# Altium schematic sheet 04-BOUTON_LCD.SchDoc(04-BOUTON_LCD)
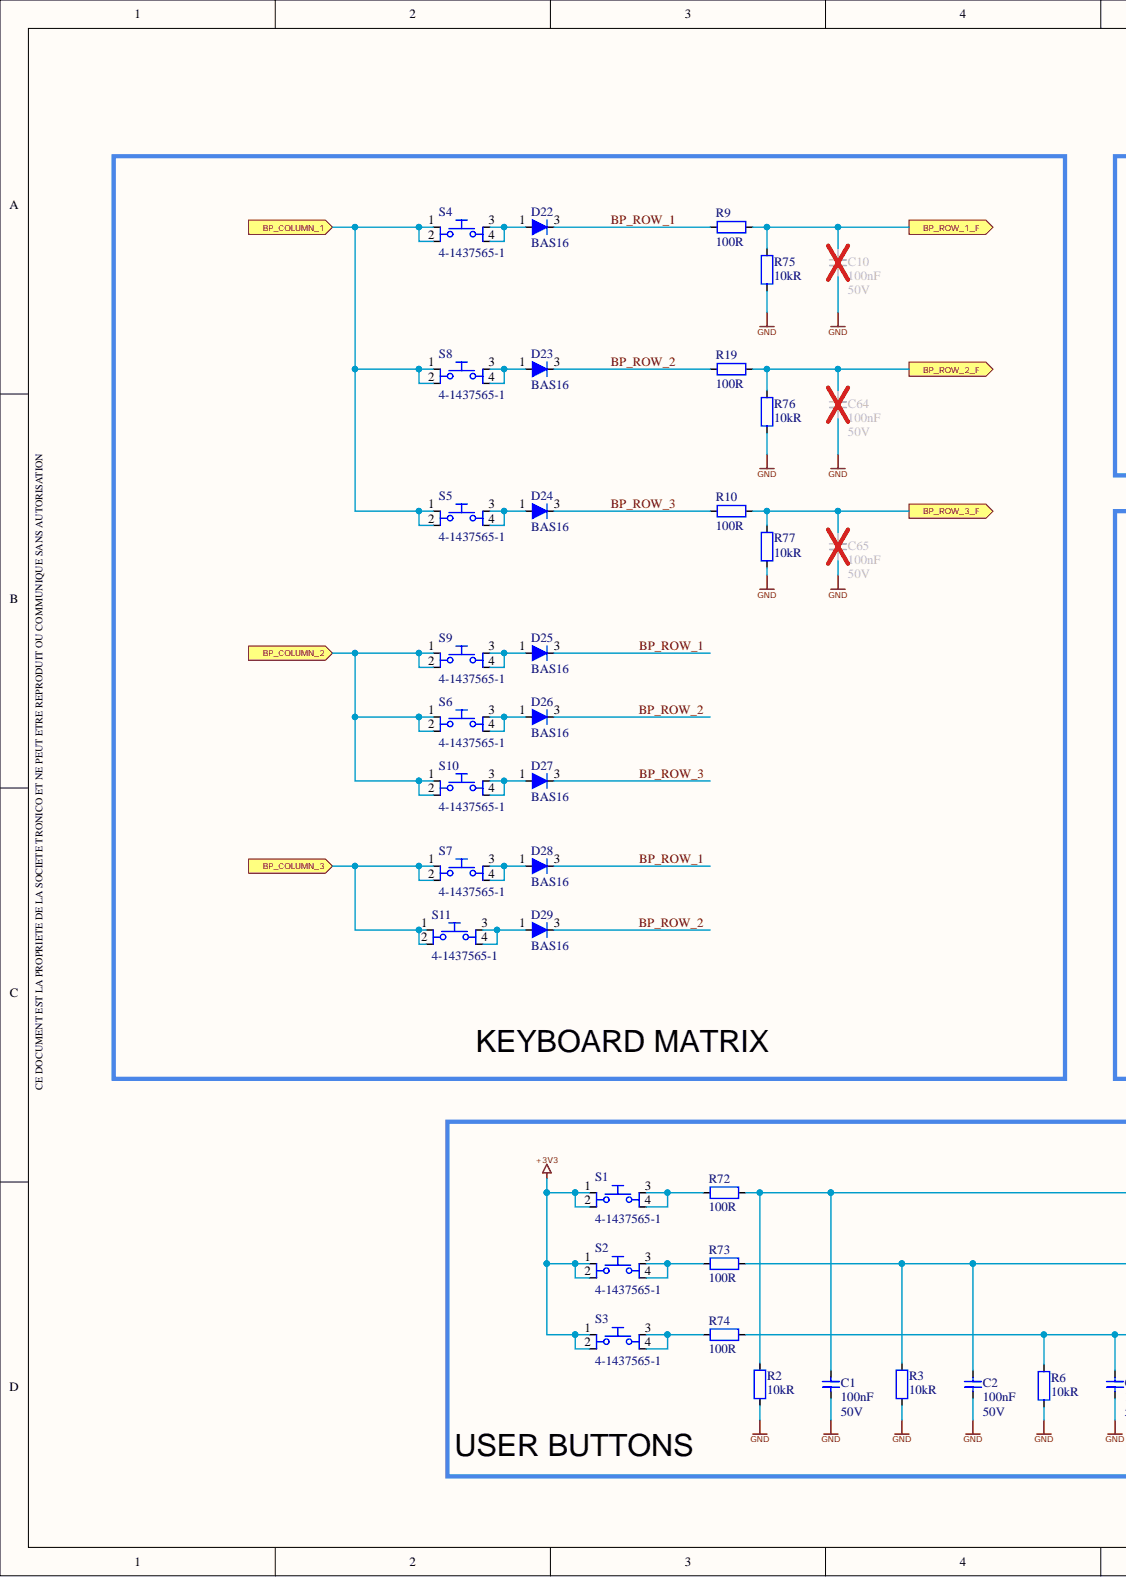
[diagram p0: 04-BOUTON_LCD.SchDoc, left]
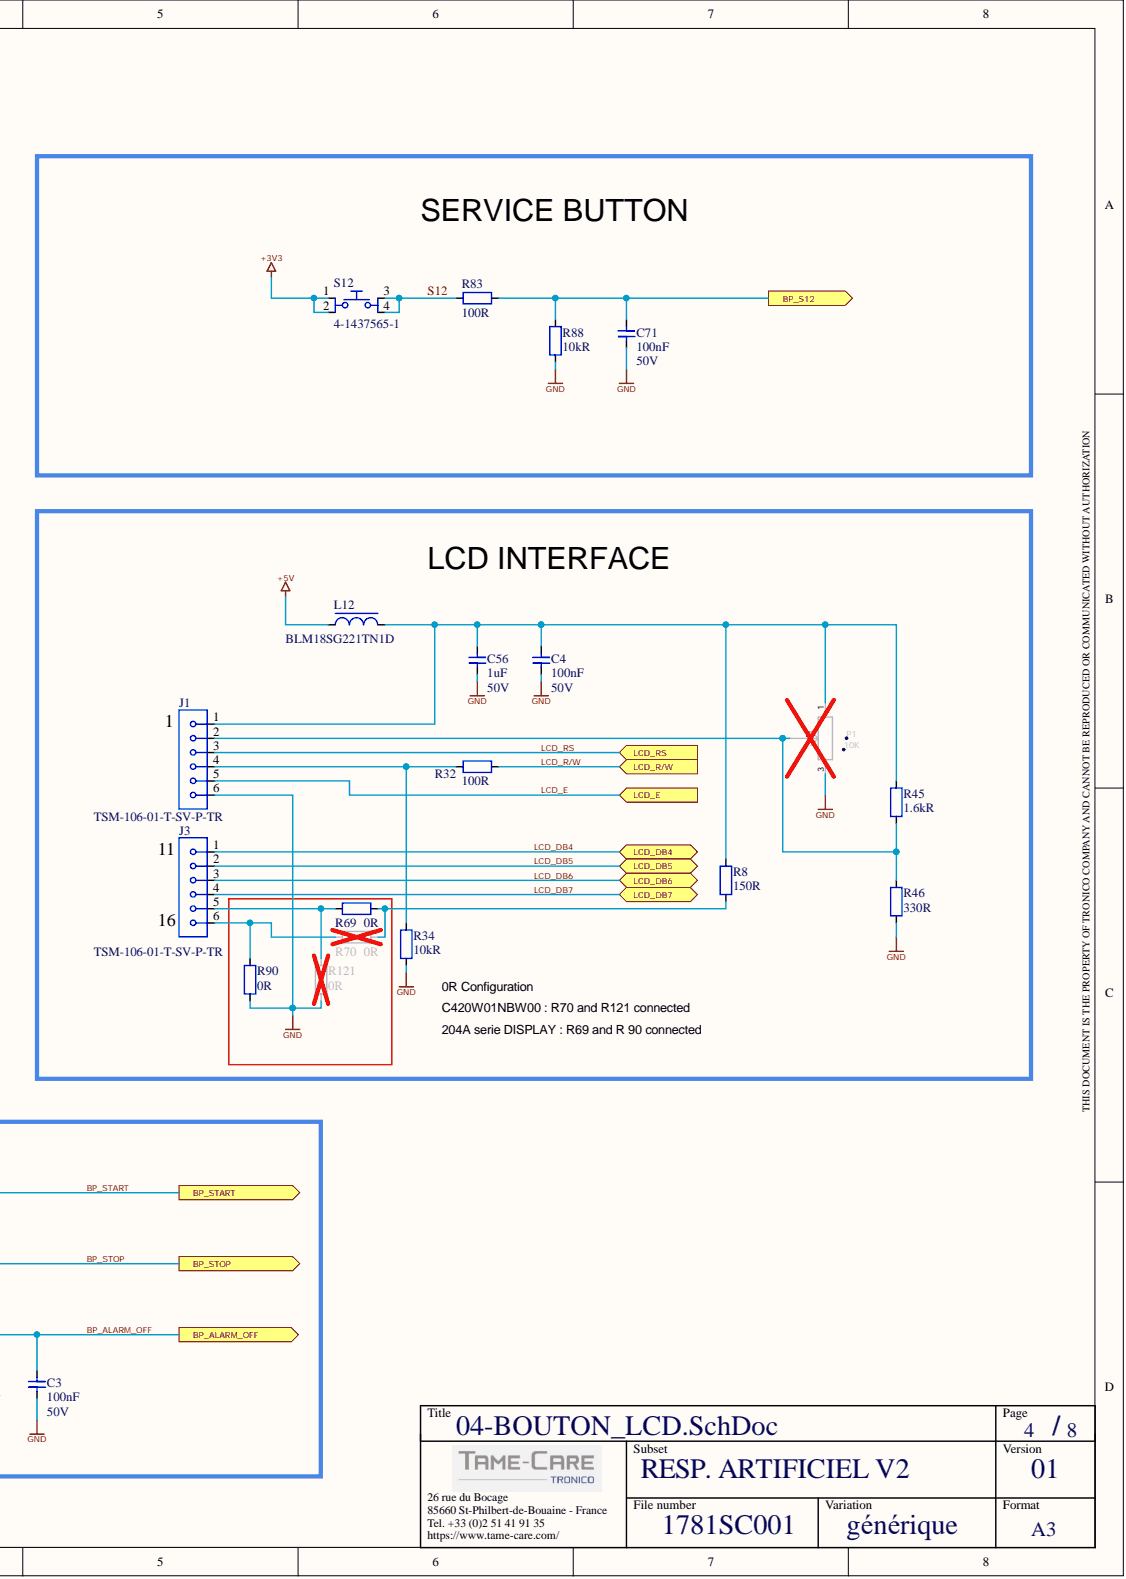
[diagram p1: 04-BOUTON_LCD.SchDoc, right]

## Parts
C1  100nF  Parameter 1: 50V  [CAPC_0603X094_T]
C2  100nF  Parameter 1: 50V  [CAPC_0603X094_T]
C3  100nF  Parameter 1: 50V  [CAPC_0603X094_T]
C4  100nF  Parameter 1: 50V  [CAPC_0603X094_T]
C10  100nF  Parameter 1: 50V  [CAPC_0603X094_T]
C56  1uF  Parameter 1: 50V  [CAPC_0603X080_T]
C64  100nF  Parameter 1: 50V  [CAPC_0603X094_T]
C65  100nF  Parameter 1: 50V  [CAPC_0603X094_T]
C71  100nF  Parameter 1: 50V  [CAPC_0603X094_T]
D22  BAS16  [SOT23_T]
D23  BAS16  [SOT23_T]
D24  BAS16  [SOT23_T]
D25  BAS16  [SOT23_T]
D26  BAS16  [SOT23_T]
D27  BAS16  [SOT23_T]
D28  BAS16  [SOT23_T]
D29  BAS16  [SOT23_T]
J1  TSM-106-01-T-SV-P-TR  [CNT_SAMTEC_TSM-106-01-X-SV_B]
J3  TSM-106-01-T-SV-P-TR  [CNT_SAMTEC_TSM-106-01-X-SV_B]
L12  BLM18SG221TN1D  [IND_0603X094_T]
P1  value 10K  [3214W]  (hidden comment: POT10K-3224W-BOURNS-CMS)
R2  10kR  [RESC_0603X045_T]
R3  10kR  [RESC_0603X045_T]
R6  10kR  [RESC_0603X045_T]
R8  150R  [RESC_0603X045_T]
R9  100R  [RESC_0603X045_T]
R10  100R  [RESC_0603X045_T]
R19  100R  [RESC_0603X045_T]
R32  100R  [RESC_0603X045_T]
R34  10kR  [RESC_0603X045_T]
R45  1.6kR  [RESC_0603X045_T]
R46  330R  [RESC_0603X045_T]
R69  0R  [RESC_0603X045_T]
R70  0R  [RESC_0603X045_T]
R72  100R  [RESC_0603X045_T]
R73  100R  [RESC_0603X045_T]
R74  100R  [RESC_0603X045_T]
R75  10kR  [RESC_0603X045_T]
R76  10kR  [RESC_0603X045_T]
R77  10kR  [RESC_0603X045_T]
R83  100R  [RESC_0603X045_T]
R88  10kR  [RESC_0603X045_T]
R90  0R  [RESC_0603X045_T]
R121  0R  [RESC_0603X045_T]
S1  4-1437565-1  [PushButon_TE-4-1437565-1_B]
S2  4-1437565-1  [PushButon_TE-4-1437565-1_B]
S3  4-1437565-1  [PushButon_TE-4-1437565-1_B]
S4  4-1437565-1  [PushButon_TE-4-1437565-1_B]
S5  4-1437565-1  [PushButon_TE-4-1437565-1_B]
S6  4-1437565-1  [PushButon_TE-4-1437565-1_B]
S7  4-1437565-1  [PushButon_TE-4-1437565-1_B]
S8  4-1437565-1  [PushButon_TE-4-1437565-1_B]
S9  4-1437565-1  [PushButon_TE-4-1437565-1_B]
S10  4-1437565-1  [PushButon_TE-4-1437565-1_B]
S11  4-1437565-1  [PushButon_TE-4-1437565-1_B]
S12  4-1437565-1  [PushButon_TE-4-1437565-1_B]

## Ports
BP_ALARM_OFF, BP_COLUMN_1, BP_COLUMN_2, BP_COLUMN_3, BP_ROW_1_F, BP_ROW_2_F, BP_ROW_3_F, BP_S12, BP_START, BP_STOP, LCD_DB4, LCD_DB5, LCD_DB6, LCD_DB7, LCD_E, LCD_R/W, LCD_RS

## Nets
+3V3: S1.1 S1.2 S2.1 S2.2 S3.1 S3.2 S12.1 S12.2
+5V: L12.1
GND: C1.1 C2.1 C3.1 C4.1 C10.1 C56.1 C64.1 C65.1 C71.1 J1.6 P1.3 R2.2 R3.2 R6.2 R34.1 R46.2 R75.2 R76.2 R77.2 R88.2 R90.2 R121.2
BP_ALARM_OFF: C3.2 R6.1 R74.1 ; port BP_ALARM_OFF
BP_COLUMN_1: S4.1 S4.2 S5.1 S5.2 S8.1 S8.2 ; port BP_COLUMN_1
BP_COLUMN_2: S6.1 S6.2 S9.1 S9.2 S10.1 S10.2 ; port BP_COLUMN_2
BP_COLUMN_3: S7.1 S7.2 S11.1 S11.2 ; port BP_COLUMN_3
BP_ROW_1: D22.3 D25.3 D28.3 R9.2
BP_ROW_1_F: C10.2 R9.1 R75.1 ; port BP_ROW_1_F
BP_ROW_2: D23.3 D26.3 D29.3 R19.2
BP_ROW_2_F: C64.2 R19.1 R76.1 ; port BP_ROW_2_F
BP_ROW_3: D24.3 D27.3 R10.2
BP_ROW_3_F: C65.2 R10.1 R77.1 ; port BP_ROW_3_F
BP_S12: C71.2 R83.1 R88.1 ; port BP_S12
BP_START: C1.2 R2.1 R72.1 ; port BP_START
BP_STOP: C2.2 R3.1 R73.1 ; port BP_STOP
LCD_DB4: J3.1 ; port LCD_DB4
LCD_DB5: J3.2 ; port LCD_DB5
LCD_DB6: J3.3 ; port LCD_DB6
LCD_DB7: J3.4 ; port LCD_DB7
LCD_E: J1.5 ; port LCD_E
LCD_R/W: R32.1 ; port LCD_R/W
LCD_RS: J1.3 ; port LCD_RS
S12: R83.2 S12.3 S12.4
NetC4_2: C4.2 C56.2 J1.1 L12.2 P1.1 R8.1 R45.1
NetD22_1: D22.1 S4.3 S4.4
NetD23_1: D23.1 S8.3 S8.4
NetD24_1: D24.1 S5.3 S5.4
NetD25_1: D25.1 S9.3 S9.4
NetD26_1: D26.1 S6.3 S6.4
NetD27_1: D27.1 S10.3 S10.4
NetD28_1: D28.1 S7.3 S7.4
NetD29_1: D29.1 S11.3 S11.4
NetJ1_2: J1.2 P1.2 R45.2 R46.1
NetJ1_4: J1.4 R32.2 R34.2
NetJ3_5: J3.5 R69.2 R121.1
NetJ3_6: J3.6 R70.2 R90.1
NetR8_2: R8.2 R69.1 R70.1
NetR72_2: R72.2 S1.3 S1.4
NetR73_2: R73.2 S2.3 S2.4
NetR74_2: R74.2 S3.3 S3.4

## Library data of the parts
C1-C4 C10 C64 C65 C71 = 23U00036: COND_CERAM CMS 0603 X7R 100nF 50V 10%; REV_NOTE: Maj Champs Techniques; REV_AUTHOR: nlelievre; REF_FAB: 06035C104KAT2A; LEVEL: 1; FAMILLE: CAPACITIFS; FAB: AVX; Database Table Name: v_bt_all; CHAMP9: -55°C/+125°C; CHAMP2: 0603; SS_FAMILLE: COND_CERAM; Simulation: CAP; Signal Integrity: Cap; REV_DATE: 16/10/2019 13:20:57; Library Name: SVNDatabase_BaseTechnique.SVNDbLib; ID_RnD: 23U00036; HelpURL: http://Bt_rnd/ds/02_capacitif/AVX/AVX_cx7r.pdf; ComponentLink2Description: Manufacturer Website; ComponentLink1Description: Manufacturer Datasheet; Component Kind: Standard; CHAMP7: 10%; CHAMP6: 50V; CHAMP5: 100nF; CHAMP3: X7R; CHAMP1: CMS; ACTIF: ACTIF
C56 = 23U00347: COND_CERAM CMS 0603 X5R 1uF 50V 10%; REV_NOTE: Maj Champs Techniques; REV_AUTHOR: nlelievre; REF_FAB: CGA3E3X5R1H105K080AB; LEVEL: 1; FAMILLE: CAPACITIFS; FAB: TDK; Database Table Name: v_bt_all; CHAMP9: -55°C/+85°C; CHAMP2: 0603; SS_FAMILLE: COND_CERAM; Simulation: CAP; Signal Integrity: Cap; REV_DATE: 16/10/2019 13:35:17; Library Name: SVNDatabase_BaseTechnique.SVNDbLib; ID_RnD: 23U00347; HelpURL: http://bt_rnd/ds/02_capacitif/TDK/mlccspec_automotive_general_midvoltage_en.pdf; ComponentLink2Description: Manufacturer Website; ComponentLink1Description: Manufacturer Datasheet; Component Kind: Standard; CHAMP7: 10%; CHAMP6: 50V; CHAMP5: 1uF; CHAMP3: X5R; CHAMP1: CMS; ACTIF: ACTIF
D22-D29 = 33U00002: DIODE_DE_SIGNAL CMS SOT23  85V 200mA -55°C/+150°C -55°C/+150°C; REV_NOTE: MaJ Champs Librairies; REV_AUTHOR: llannuzel; LEVEL: 1; FAMILLE: DIODES; FAB: FAIRCHILD; Database Table Name: v_bt_all; SS_FAMILLE: DIODE_DE_SIGNAL; REV_DATE: 02/05/2020 23:06:26; REF_FAB: BAS16; ID_RnD: 33U00002; HelpURL: http://bt_rnd/ds/03_diode/FAIRCHILD/FAIRCHILD_BAS16.pdf; ComponentLink2Description: Manufacturer Website; ComponentLink1Description: Manufacturer Datasheet; Component Kind: Standard; CHAMP10: -55°C/+150°C; CHAMP9: -55°C/+150°C; CHAMP6: 200mA; CHAMP5: 85V; CHAMP2: SOT23; CHAMP1: CMS; ACTIF: ACTIF; Library Name: SVNDatabase_BaseTechnique.SVNDbLib
J1 J3 = ABU00030: BARRETTE MALE CMS 6PTS DROIT SN P:2.54mm; REV_NOTE: Migration vers t_bt; REV_AUTHOR: lclavier; LEVEL: 1; FAMILLE: CONNECTIQUE; FAB: SAMTEC; Database Table Name: v_bt_all; CHAMP9: -55°C/+105°C; SS_FAMILLE: BARRETTE; REV_DATE: 30/04/2020 21:10:48; REF_FAB: TSM-106-01-T-SV-P-TR; ID_RnD: ABU00030; HelpURL: http://bt_rnd/ds/10_connectique/SAMTEC/tsm.pdf; ComponentLink2Description: Manufacturer Website; ComponentLink1Description: Manufacturer Datasheet; Component Kind: Standard; CHAMP8: P:2.54mm; CHAMP7: SN; CHAMP6: DROIT; CHAMP4: 6PTS; CHAMP3: CMS; CHAMP2: MALE; ACTIF: ACTIF; Library Name: SVNDatabase_BaseTechnique.SVNDbLib
L12 = 43U00016: FERRITES CMS 0603  220R 100MHz 2.5A -55°C/+125°C -55°C/+125°C; Valide / Non Valide: OK; REV_NOTE: Maj Library Ref & Library Path; REV_AUTHOR: jcaille; LEVEL: 1; FAMILLE: INDUCTIFS; FAB: MURATA; Database Table Name: v_bt_all; CHAMP2: 0603; SS_FAMILLE: FERRITES; Simulation: INDUCTOR; Signal Integrity: Inductor; REV_DATE: 10/12/2019 16:11:10; REF_FAB: BLM18SG221TN1D; ID_RnD: 43U00016; HelpURL: http://bt_rnd/ds/04_inductif/MURATA/MURATA_5151.pdf; ComponentLink2Description: Manufacturer Website; ComponentLink1Description: Manufacturer Datasheet; Component Kind: Standard; CHAMP10: -55°C/+125°C; CHAMP9: -55°C/+125°C; CHAMP7: 2.5A; CHAMP6: 100MHz; CHAMP5: 220R; CHAMP1: CMS; ACTIF: ACTIF; Library Name: SVNDatabase_BaseTechnique.SVNDbLib
P1 = POT10K-3224W-BOURNS-CMS: PART NUMBER: 3224W-1-103; PART DESC: Potentiomètre CMS - 12T 0.25W 10%; TOLERANCE: 10%; REF DISTRI: NM; MFG #1: BOURNS; DATE DE VERIFICATION: 05/07/2007 FM; DATE DE CREATION: 02/06/2007 DR; CODE GPAO: 1140035G; PCB DECAL: 3214W; Component Kind: Standard
R2 R3 R6 R34 R75-R77 R88 = 11U00108: REST CMS 0603 100PPM 10kR 1/10W 1% -55°C/+155°C; Valide / Non Valide: OK; REV_NOTE: MaJ description; REV_AUTHOR: jrenvoise; REF_FAB: RC0603FR-0710KL; LEVEL: 1; FAMILLE: RESISTIFS; FAB: YAGEO; Database Table Name: v_bt_all; CHAMP2: 0603; SS_FAMILLE: REST; Simulation: RESISTOR; Signal Integrity: Res; REV_DATE: 12/09/2018 10:54:26; ID_RnD: 11U00108; HelpURL: http://bt_rnd/ds/01_resistif/yageo/RC-L_Series.pdf; ComponentLink2Description: Manufacturer Website; ComponentLink1Description: Manufacturer Datasheet; Component Kind: Standard; CHAMP9: -55°C/+155°C; CHAMP7: 1%; CHAMP6: 1/10W; CHAMP5: 10kR; CHAMP3: 100PPM; CHAMP1: CMS; ACTIF: ACTIF; Library Name: SVNDatabase_BaseTechnique.SVNDbLib
R8 = 11U00074: REST CMS 0603 100PPM 150R 1/10W 1% -55°C/+155°C; Valide / Non Valide: OK; REV_NOTE: MaJ description; REV_AUTHOR: jrenvoise; REF_FAB: RC0603FR-07150RL; LEVEL: 1; FAMILLE: RESISTIFS; FAB: YAGEO; Database Table Name: v_bt_all; CHAMP2: 0603; SS_FAMILLE: REST; Simulation: RESISTOR; Signal Integrity: Res; REV_DATE: 12/09/2018 10:54:26; ID_RnD: 11U00074; HelpURL: http://bt_rnd/ds/01_resistif/yageo/RC-L_Series.pdf; ComponentLink2Description: Manufacturer Website; ComponentLink1Description: Manufacturer Datasheet; Component Kind: Standard; CHAMP9: -55°C/+155°C; CHAMP7: 1%; CHAMP6: 1/10W; CHAMP5: 150R; CHAMP3: 100PPM; CHAMP1: CMS; ACTIF: ACTIF; Library Name: SVNDatabase_BaseTechnique.SVNDbLib
R9 R10 R19 R32 R72-R74 R83 = 11U00071: REST CMS 0603 100PPM 100R 1/10W 1% -55°C/+155°C; Valide / Non Valide: OK; REV_NOTE: MaJ description; REV_AUTHOR: jrenvoise; REF_FAB: RC0603FR-07100RL; LEVEL: 1; FAMILLE: RESISTIFS; FAB: YAGEO; Database Table Name: v_bt_all; CHAMP2: 0603; SS_FAMILLE: REST; Simulation: RESISTOR; Signal Integrity: Res; REV_DATE: 12/09/2018 10:54:26; ID_RnD: 11U00071; HelpURL: http://bt_rnd/ds/01_resistif/yageo/RC-L_Series.pdf; ComponentLink2Description: Manufacturer Website; ComponentLink1Description: Manufacturer Datasheet; Component Kind: Standard; CHAMP9: -55°C/+155°C; CHAMP7: 1%; CHAMP6: 1/10W; CHAMP5: 100R; CHAMP3: 100PPM; CHAMP1: CMS; ACTIF: ACTIF; Library Name: SVNDatabase_BaseTechnique.SVNDbLib
R45 = 11U01012: REST CMS 0603 100PPM 1.6kR 1/10W 1% 75V; Valide / Non Valide: OK; REV_NOTE: Migration vers t_bt; REV_AUTHOR: jcaille; REF_FAB: CRCW06031K60FKEA; LEVEL: 1; FAMILLE: RESISTIFS; FAB: VISHAY; Database Table Name: v_bt_all; CHAMP9: -55°C/+155°C; CHAMP2: 0603; SS_FAMILLE: REST; Simulation: RESISTOR; Signal Integrity: Res; REV_DATE: 17/04/2020 11:05:27; ID_RnD: 11U01012; HelpURL: http://bt_rnd/ds/01_resistif/Vishay/dcrcwe3.pdf; ComponentLink2Description: Manufacturer Website; ComponentLink1Description: Manufacturer Datasheet; Component Kind: Standard; CHAMP8: 75V; CHAMP7: 1%; CHAMP6: 1/10W; CHAMP5: 1.6kR; CHAMP3: 100PPM; CHAMP1: CMS; ACTIF: ACTIF; Library Name: SVNDatabase_BaseTechnique.SVNDbLib
R46 = 11U00079: REST CMS 0603 100PPM 330R 1/10W 1% -55°C/+155°C; Valide / Non Valide: OK; REV_NOTE: MaJ description; REV_AUTHOR: jrenvoise; REF_FAB: RC0603FR-07330RL; LEVEL: 1; FAMILLE: RESISTIFS; FAB: YAGEO; Database Table Name: v_bt_all; CHAMP2: 0603; SS_FAMILLE: REST; Simulation: RESISTOR; Signal Integrity: Res; REV_DATE: 12/09/2018 10:54:26; ID_RnD: 11U00079; HelpURL: http://bt_rnd/ds/01_resistif/yageo/RC-L_Series.pdf; ComponentLink2Description: Manufacturer Website; ComponentLink1Description: Manufacturer Datasheet; Component Kind: Standard; CHAMP9: -55°C/+155°C; CHAMP7: 1%; CHAMP6: 1/10W; CHAMP5: 330R; CHAMP3: 100PPM; CHAMP1: CMS; ACTIF: ACTIF; Library Name: SVNDatabase_BaseTechnique.SVNDbLib
R69 R70 R90 R121 = 11U00009: REST CMS 0603 100PPM  0R 1/10W 20mR -55°C/+155°C; Valide / Non Valide: OK; REV_NOTE: MaJ Champs URL; REV_AUTHOR: jrenvoise; REF_FAB: CRCW06030000Z0EA; LEVEL: 1; FAMILLE: RESISTIFS; FAB: VISHAY; Database Table Name: v_bt_all; CHAMP2: 0603; SS_FAMILLE: REST; Simulation: RESISTOR; Signal Integrity: Res; REV_DATE: 24/10/2018 10:43:14; ID_RnD: 11U00009; HelpURL: http://bt_rnd/ds/01_resistif/vishay/Vishay_D-CRCWE3.pdf; ComponentLink2Description: Manufacturer Website; ComponentLink1URL: http://www.vishay.com/docs/20035/dcrcwe3.pdf; ComponentLink1Description: Manufacturer Datasheet; Component Kind: Standard; CHAMP9: -55°C/+155°C; CHAMP8: 20mR; CHAMP6: 1/10W; CHAMP5: 0R; CHAMP3: 100PPM; CHAMP1: CMS; ACTIF: ACTIF; Library Name: SVNDatabase_BaseTechnique.SVNDbLib
S1-S12 = 81U00012: BOUTON_POUSSOIR CMS 4PTS DROIT 12Vc.a.  50mA; REV_NOTE: MaJ Champs Librairies; REV_AUTHOR: lclavier; LEVEL: 1; FAMILLE: COMMUTATION; FAB: TE CONNECTIVITY; Database Table Name: v_bt_all; CHAMP9: -35°C/+85°C; SS_FAMILLE: BOUTON_POUSSOIR; REV_DATE: 20/04/2020 18:16:49; REF_FAB: 4-1437565-1; ID_RnD: 81U00012; HelpURL: http://bt_rnd/ds/08_commutation/TE/0900766b814a3369.pdf; ComponentLink2Description: Manufacturer Website; ComponentLink1Description: Manufacturer Datasheet; Component Kind: Standard; CHAMP7: 12Vc.a.  50mA; CHAMP6: DROIT; CHAMP2: 4PTS; CHAMP1: CMS; ACTIF: ACTIF; Library Name: SVNDatabase_BaseTechnique.SVNDbLib
